# Altium schematic sheet PSU.SchDoc(PSU)
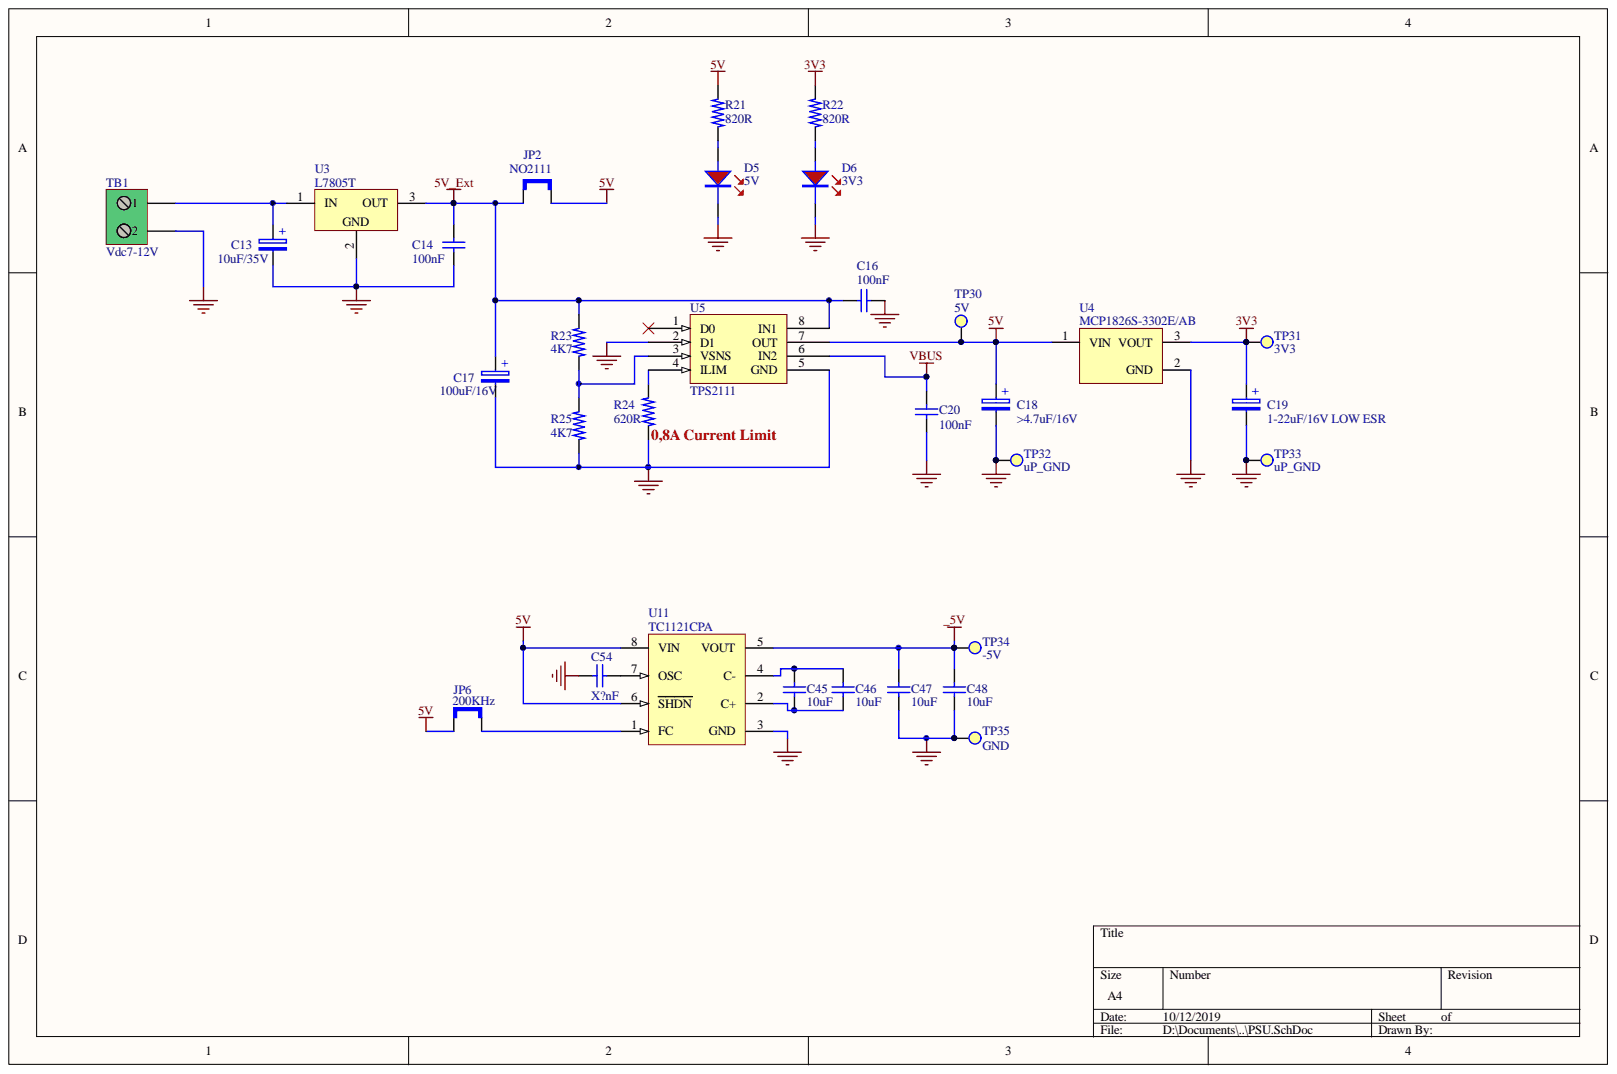
[diagram p0: PSU.SchDoc]

## Parts
C13  10uF/35V  [CAP_RAD_P04_D5x11]
C14  100nF  [0805Big_C]
C16  100nF  [0805Big_C]
C17  100uF/16V  [CAP_RAD_P04_D8x11]
C18  >4.7uF/16V  [CAP_RAD_P04_D5x11]
C19  1-22uF/16V LOW ESR  [CAP_RAD_P04_D5x11]
C20  100nF  [0805Big_C]
C45  10uF  [1206_0805_R]
C46  10uF  [1206_0805_R]
C47  10uF  [1206_0805_R]
C48  10uF  [1206_0805_R]
C54  X?nF  [0805Big_C]
D5  5V  [0805_Led]
D6  3V3  [0805_Led]
JP2  NO2111  [SIP2]
JP6  200KHz  [SIP2]
R21  820R  [0805Big_R]
R22  820R  [0805Big_R]
R23  4K7  [0805Big_R]
R24  620R  [0805Big_R]
R25  4K7  [0805Big_R]
TB1  Vdc7-12V  [TB_2]
TP30  5V  [PIN0MM9]
TP31  3V3  [PIN0MM9]
TP32  uP_GND  [PIN0MM9]
TP33  uP_GND  [PIN0MM9]
TP34  -5V  [PIN0MM9]
TP35  GND  [PIN0MM9]
U3  L7805T  [TO220]
U4  MCP1826S-3302E/AB  [TO220]
U5  TPS2111  [TSSOP-8]
U11  TC1121CPA  [DIP-8]

## Nets
3V3: C19.1 R22.1 TP31.1 U4.3
5V: C18.1 JP2.2 JP6.1 R21.1 TP30.1 U4.1 U5.7(OUT) U11.6(SHDN) U11.8(VIN)
5V_Ext: C14.1 C16.1 C17.1 JP2.1 R23.1 U3.3 U5.8(IN1)
_5V: C47.1 C48.1 TP34.1 U11.5(VOUT)
GND: C13.2 C14.2 C16.2 C17.2 C18.2 C19.2 C20.2 C47.2 C48.2 C54.2 D5.K D6.K R24.2 R25.2 TB1.2 TP32.1 TP33.1 TP35.1 U3.2 U4.2 U5.2(D1) U5.5(GND) U11.3(GND)
VBUS: C20.1 U5.6(IN2)
NetC13_1: C13.1 TB1.1 U3.1
NetC45_1: C45.1 C46.1 U11.4(C-)
NetC45_2: C45.2 C46.2 U11.2(C+)
NetC54_1: C54.1 U11.7(OSC)
NetD5_A: D5.A R21.2
NetD6_A: D6.A R22.2
NetJP6_2: JP6.2 U11.1(FC)
NetR23_2: R23.2 R25.1 U5.3(VSNS)
NetR24_1: R24.1 U5.4(ILIM)

Not connected: U5 1(D0)

## Library data of the parts
C13 C17-C19 = CapElectr: Capacitor Electrolytic; Simulation: Model Name; Library Name: MyLibrary.IntLib; Component Kind: Standard
C14 C16 C20 C45-C48 C54 = CapCerSMD: Capacitor Ceramic SMD; Simulation: Model Name; Library Name: MyLibrary.IntLib; Component Kind: Standard
D5 D6 = LED: Library Name: MyComponents.SchLib; Description: LED; Component Kind: Standard
JP2 JP6 = JUMPER: Library Name: MyLibrary.IntLib; Description: Jumper; Component Kind: Standard
R21-R25 = ResSMD: Resistor SMD; Simulation: Model Name; Library Name: MyLibrary.IntLib; Component Kind: Standard
TB1 = CON2: PCB screw terminal block 2 pins; Library Name: MyLibrary.IntLib; Component Kind: Standard
TP30-TP35 = PIN_TEST: Test Point; Library Name: MyLibrary.IntLib; Component Kind: Standard
U3 = L7805T: Voltage Regulator, Positive; Simulation: LM7805; Library Name: AnalogIC.SchLib; Component Kind: Standard
U4 = MCP1826S-3302E/AB: 1000 mA, Low Voltage, Low Quiescent Current LDO Regulator, 3-Pin TO-220, Extended Temperature; Supplier Part Number 3: 27M0036; Supplier Part Number 2: 1578423; Supplier Part Number 1: MCP1826S-3302E/AB-ND; Supplier 3: Newark; Supplier 2: Farnell; Supplier 1: Digi-Key; PackageVersion: revBB, Aug-2009; PackageReference: TO-220-AB3; PackageDescription: 3-Lead Plastic Transistor Outline (AB) [TO-220]; Library Name: Microchip Power Mgt Line Regulator.IntLib; FirstPublished: 29-Jan-2009; DatasheetVersion: revA, Aug-2007; ComponentLink3URL: http://www.microchip.com/; ComponentLink3Description: Microchip.com Home; ComponentLink2URL: http://www.microchip.com/stellent/groups/techpub_sg/documents/packagingspec/en012702.pdf; ComponentLink2Description: Packaging Specification; ComponentLink1URL: http://ww1.microchip.com/downloads/en/DeviceDoc/22057a.pdf; ComponentLink1Description: Datasheet; Component Kind: Standard; Publisher: Altium Limited
U5 = TPS2111: Autoswitch Power multiplexer; Library Name: MyComponents.SchLib; Component Kind: Standard
U11 = TC1121CPA: 100mA Charge Pump Voltage Converter with Shutdown, 8-Pin PDIP, Commercial Temperature; Supplier Part Number 3: 96K4007; Supplier Part Number 2: 1252905; Supplier Part Number 1: TC1121CPA-ND; Supplier 3: Newark; Supplier 2: Farnell; Supplier 1: Digi-Key; PackageVersion: revBB, Aug-2009; PackageReference: PDIP300-PA8; PackageDescription: 8-Lead Plastic Dual In-Line (PA) - 300 mil Body [PDIP]; Library Name: Microchip Power Mgt Charge Pump.IntLib; FirstPublished: 29-Jan-2009; DatasheetVersion: revC, Jun-2006; ComponentLink3URL: http://www.microchip.com/; ComponentLink3Description: Microchip.com Home; ComponentLink2URL: http://www.microchip.com/stellent/groups/techpub_sg/documents/packagingspec/en012702.pdf; ComponentLink2Description: Packaging Specification; ComponentLink1URL: http://ww1.microchip.com/downloads/en/DeviceDoc/21358C.pdf; ComponentLink1Description: Datasheet; Component Kind: Standard; Code_JEDEC: MS-001-BA; Publisher: Altium Limited
